# Altium schematic sheet Power_VCC.SchDoc("Power VCC")
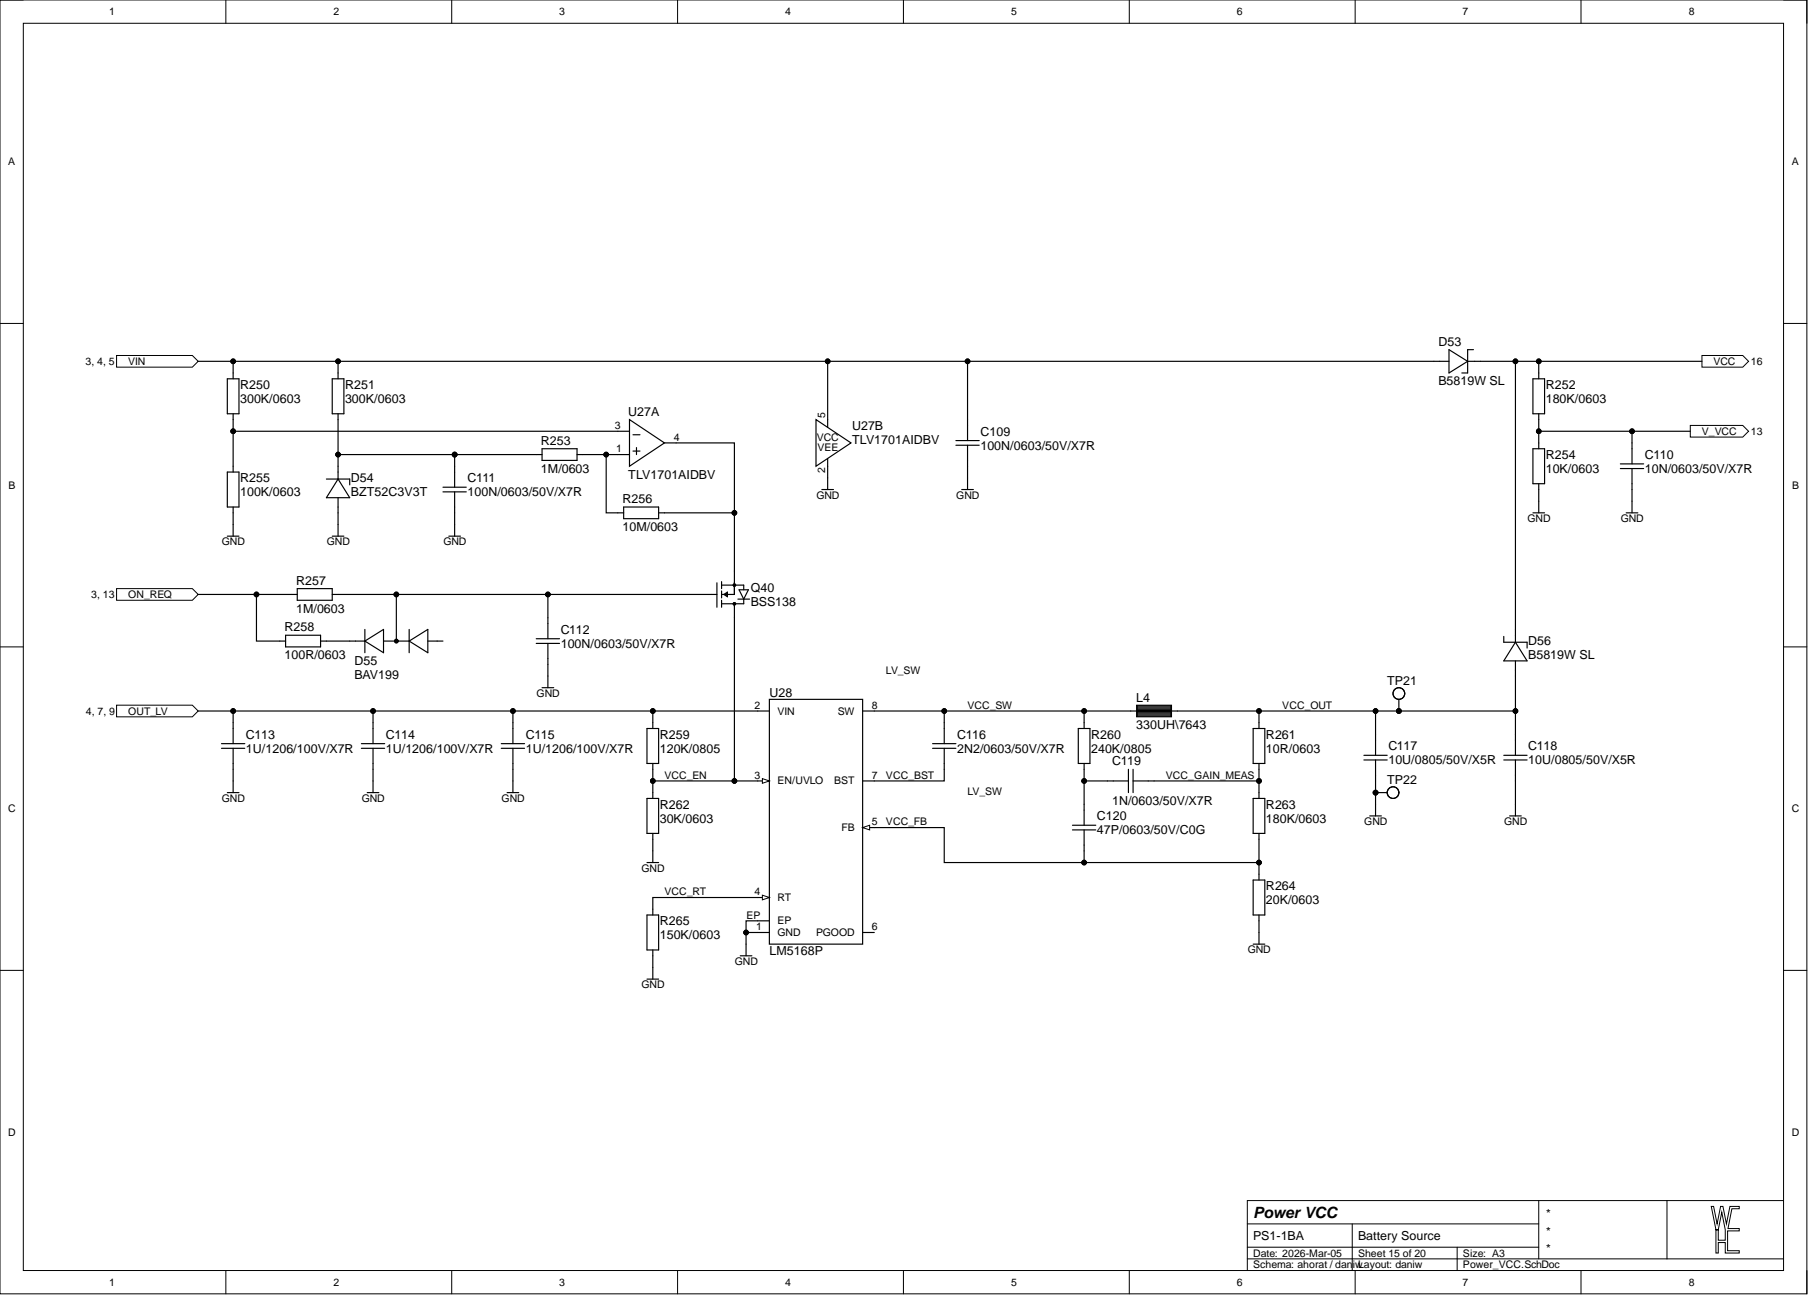
[diagram p0: Power_VCC.SchDoc]

## Parts
C109  100N/0603/50V/X7R  [CAP0603_X7R]
C110  10N/0603/50V/X7R  [CAP0603_X7R]
C111  100N/0603/50V/X7R  [CAP0603_X7R]
C112  100N/0603/50V/X7R  [CAP0603_X7R]
C113  1U/1206/100V/X7R  [CAP1206-X7R]
C114  1U/1206/100V/X7R  [CAP1206-X7R]
C115  1U/1206/100V/X7R  [CAP1206-X7R]
C116  2N2/0603/50V/X7R  [CAP0603_X7R]
C117  10U/0805/50V/X5R  [CAP0805_X7R]
C118  10U/0805/50V/X5R  [CAP0805_X7R]
C119  1N/0603/50V/X7R  [CAP0603_X7R]
C120  47P/0603/50V/C0G  [CAP0603_C0G]
D53  B5819W SL  [SOD3718X125]
D54  BZT52C3V3T  [SOD1608X065]
D55  BAV199  [SOT2395P280X125-3-SE]
D56  B5819W SL  [SOD3718X125]
L4  330UH\7643  [INDM7676X43]
Q40  BSS138  [SOT2395P280X125-3-SE]
R250  300K/0603  [R0603-0.40-WW]
R251  300K/0603  [R0603-0.40-WW]
R252  180K/0603  [R0603-0.40-WW]
R253  1M/0603  [R0603-0.40-WW]
R254  10K/0603  [R0603-0.40-WW]
R255  100K/0603  [R0603-0.40-WW]
R256  10M/0603  [R0603-0.40-WW]
R257  1M/0603  [R0603-0.40-WW]
R258  100R/0603  [R0603-0.40-WW]
R259  120K/0805  [R0805-0.50-WW]
R260  240K/0805  [R0805-0.50-WW]
R261  10R/0603  [R0603-0.40-WW]
R262  30K/0603  [R0603-0.40-WW]
R263  180K/0603  [R0603-0.40-WW]
R264  20K/0603  [R0603-0.40-WW]
R265  150K/0603  [R0603-0.40-WW]
TP21  TP_THD_1.5/0.8  [TP_THD_1.5/0.8]  (value not drawn)  (no BOM)
TP22  TP_THD_1.5/0.8  [TP_THD_1.5/0.8]  (value not drawn)  (no BOM)
U27  TLV1701AIDBV  [SOT2395P280X125-5-NW]  (parts A,B)
U28  LM5168P  [SOP127P600X155-8-EP330x240-NW]

## Ports
ON_REQ, OUT_LV, V_VCC, VCC, VIN

## Nets
GND: C109.2 C110.2 C111.2 C112.2 C113.2 C114.2 C115.2 C117.2 C118.2 D54.2 R254.2 R255.2 R262.2 R264.2 R265.2 TP22.1 U27.2 U28.1(GND) U28.EP
ON_REQ: R257.1 R258.1 ; port ON_REQ
OUT_LV: C113.1 C114.1 C115.1 R259.1 U28.2(VIN) ; port OUT_LV
V_VCC: C110.1 R252.2 R254.1 ; port V_VCC
VCC: D53.1 D56.1 R252.1 ; port VCC
VCC_BST: C116.2 U28.7(BST)
VCC_EN: Q40.3 R259.2 R262.1 U28.3(EN/UVLO)
VCC_FB: C120.2 R263.2 R264.1 U28.5(FB)
VCC_GAIN_MEAS: C119.2 R261.2 R263.1
VCC_OUT: C117.1 C118.1 D56.2 L4.2 R261.1 TP21.1
VCC_RT: R265.1 U28.4(RT)
VCC_SW: C116.1 L4.1 R260.1 U28.8(SW)
VIN: C109.1 D53.2 R250.1 R251.1 U27.5 ; port VIN
NetC111_1: C111.1 D54.1 R251.2 R253.1
NetC112_1: C112.1 D55.3 Q40.1 R257.2
NetC119_1: C119.1 C120.1 R260.2
NetD55_1: D55.1
NetD55_2: D55.2 R258.2
NetQ40_2: Q40.2 R256.2 U27.4
NetR250_2: R250.2 R255.1 U27.3
NetR253_2: R253.2 R256.1 U27.1
NetU28_6: U28.6(PGOOD)

## Library data of the parts
C109 C111 C112 = 100N/0603/50V/X7R: CAP SM 0603 100nF 50V X7R; Manufacturer: GENERIC; Manufacturer 1: YAGEO; Manufacturer Part Number 1: CC0603KPX7R9BB104; Absolute Value: 1E-7; Database Table Name: C$; Eurocircuits GPN: GPC0603104; Component Kind: Standard; HelpURL: https://www.yageo.com/upload/media/product/app/datasheet/mlcc/upy-gphc_x7r_6_3v-to-250v.pdf; JLC Type: Basic; Manufacturer 2: YAGEO; Manufacturer Part Number 2: CC0603MRX7R9BB104; Manufacturer Part Number 3: CC0603KRX7R9BB104; Package: 0603; Part Number: 100N/0603/50V/X7R; Supplier 1: Digi-Key; Supplier 2: Digi-Key; Supplier 3: LCSC; Supplier Part Number 1: 311-3567-1-ND; Supplier Part Number 2: 311-4110-1-ND; Supplier Part Number 3: C14663; Value: 100nF; Library Name: daniw_altium_lib.DbLib
C110 = 10N/0603/50V/X7R: CAP SM 0603 10nF 50V X7R; Manufacturer: GENERIC; Manufacturer 1: YAGEO; Manufacturer Part Number 1: CC0603KPX7R9BB103; Absolute Value: 1E-8; Database Table Name: C$; Eurocircuits GPN: GPC0603103; Component Kind: Standard; HelpURL: https://www.yageo.com/upload/media/product/app/datasheet/mlcc/upy-gphc_x7r_6_3v-to-250v.pdf; Manufacturer 2: YAGEO; Manufacturer Part Number 2: CC0603KRX7R9BB103; Manufacturer Part Number 3: CC0603KRX7R9BB103; Package: 0603; Part Number: 10N/0603/50V/X7R; Supplier 1: Digi-Key; Supplier 2: Digi-Key; Supplier 3: LCSC; Supplier Part Number 1: 311-1572-1-ND; Supplier Part Number 2: 311-1085-1-ND; Supplier Part Number 3: C100042; Value: 10nF; Library Name: daniw_altium_lib.DbLib
C113-C115 = 1U/1206/100V/X7R: CAP SM 1206 1uF 100V X7R; Manufacturer: GENERIC; Manufacturer 1: Samsung Electro-Mechanics; Manufacturer Part Number 1: CL31B105KCHNNNE; Absolute Value: 1E-6; Database Table Name: C$; Component Kind: Standard; HelpURL: https://mm.digikey.com/Volume0/opasdata/d220001/medias/docus/41/CL31B105KCHNNNE_Spec.pdf; Manufacturer Part Number 3: TCC1206X7R105K101HT; Package: 1206; Part Number: 1U/1206/100V/X7R; Supplier 1: Digi-Key; Supplier 3: LCSC; Supplier Part Number 1: 1276-1838-1-ND; Supplier Part Number 3: C282823; Value: 1UF; Library Name: daniw_altium_lib.DbLib
C116 = 2N2/0603/50V/X7R: CAP SM 0603 2.2nF 50V X7R; Manufacturer: GENERIC; Manufacturer 1: YAGEO; Manufacturer Part Number 1: CC0603KPX7R9BB222; Absolute Value: 2.2E-9; Database Table Name: C$; Eurocircuits GPN: GPC0603222; Component Kind: Standard; HelpURL: https://www.yageo.com/upload/media/product/app/datasheet/mlcc/upy-gphc_x7r_6_3v-to-250v.pdf; JLC Type: Basic; Manufacturer 2: YAGEO; Manufacturer Part Number 2: CC0603KRX7R9BB222; Manufacturer Part Number 3: 0603B222K500NT; Package: 0603; Part Number: 2N2/0603/50V/X7R; Supplier 1: Digi-Key; Supplier 2: Digi-Key; Supplier 3: LCSC; Supplier Part Number 1: 13-CC0603KPX7R9BB222CT-ND; Supplier Part Number 2: 311-1081-1-ND; Supplier Part Number 3: C1604; Value: 2.2nF; Library Name: daniw_altium_lib.DbLib
C117 C118 = 10U/0805/50V/X5R: CAP SM 0805 10uF 50V X5R; Manufacturer: GENERIC; Manufacturer 1: Murata Electronics; Manufacturer Part Number 1: GRM21BR61H106KE43K; Absolute Value: 1E-5; Database Table Name: C$; Eurocircuits GPN: GPN0805106-50-X5R; Component Kind: Standard; HelpURL: https://search.murata.co.jp/Ceramy/image/img/A01X/G101/ENG/GRM21BR61H106KE43-01A.pdf; JLC Type: Basic; Manufacturer Part Number 3: GRM21BR61H106KE43L; Package: 0805; Part Number: 10U/0805/50V/X5R; Supplier 1: Digi-Key; Supplier 3: LCSC; Supplier Part Number 1: 490-GRM21BR61H106KE43KCT-ND; Supplier Part Number 3: C440198; Value: 10uF; Library Name: daniw_altium_lib.DbLib
C119 = 1N/0603/50V/X7R: CAP SM 0603 1.0nF 50V X7R; Manufacturer: GENERIC; Manufacturer 1: YAGEO; Manufacturer Part Number 1: CC0603KPX7R9BB102; Absolute Value: 1E-9; Database Table Name: C$; Eurocircuits GPN: GPC0603102; Component Kind: Standard; HelpURL: https://www.yageo.com/upload/media/product/app/datasheet/mlcc/upy-gphc_x7r_6_3v-to-250v.pdf; JLC Type: Basic; Manufacturer 2: YAGEO; Manufacturer Part Number 2: CC0603KRX7R9BB102; Manufacturer Part Number 3: CL10B102KB8NNNC; Package: 0603; Part Number: 1N/0603/50V/X7R; Supplier 1: Digi-Key; Supplier 2: Digi-Key; Supplier 3: LCSC; Supplier Part Number 1: 13-CC0603KPX7R9BB102CT-ND; Supplier Part Number 2: 311-1080-1-ND; Supplier Part Number 3: C1588; Value: 1.0nF; Library Name: daniw_altium_lib.DbLib
C120 = 47P/0603/50V/C0G: CAP SM 0603 47pF 50V C0G; Manufacturer: GENERIC; Manufacturer 1: YAGEO; Manufacturer Part Number 1: CC0603JRNPO9BN470; Absolute Value: 4.7E-11; Database Table Name: C$; Eurocircuits GPN: GPC0603470-C0G; Component Kind: Standard; HelpURL: https://www.yageo.com/upload/media/product/productsearch/datasheet/mlcc/UPY-GP_NP0_16V-to-50V_18.pdf; JLC Type: Basic; Manufacturer 2: YAGEO; Manufacturer Part Number 2: CC0603KRNPO9BN470; Manufacturer Part Number 3: CL10C470JB8NNNC; Package: 0603; Part Number: 47P/0603/50V/C0G; Supplier 1: Digi-Key; Supplier 2: Digi-Key; Supplier 3: LCSC; Supplier Part Number 1: 311-1065-1-ND; Supplier Part Number 2: 311-4037-1-ND; Supplier Part Number 3: C1671; Value: 47pF; Library Name: daniw_altium_lib.DbLib
D53 D56 = B5819W SL: 40V 600mV@1A 1A SOD-123 Schottky Barrier Diodes; Manufacturer: GENERIC; Manufacturer 1: SMC Diode Solutions; Database Table Name: D$; Absolute Value: 40V; Component Kind: Standard; HelpURL: https://datasheet.lcsc.com/lcsc/2304140030_Jiangsu-Changjing-Electronics-Technology-Co---Ltd--B5819W-SL_C8598.pdf; JLC Type: Basic; Manufacturer 3: Jiangsu Changjing Electronics Technology Co., Ltd.; Manufacturer Part Number 1: B5819WSL; Manufacturer Part Number 3: B5819W SL; Package: SOD-123; Part Number: B5819W SL; Supplier 1: Digikey; Supplier 3: LCSC; Supplier Part Number 1: 1655-B5819WSLCT-ND; Supplier Part Number 3: C8598; Value: B5819W SL; Library Name: daniw_altium_lib.DbLib
D54 = BZT52C3V3T: DIODE ZENER 3.3V 300MW SOD523; Manufacturer: Diodes Incorporated; Manufacturer Part Number 1: BZT52C3V3T-7; Database Table Name: D$; Absolute Value: 3.3 V; Component Kind: Standard; HelpURL: https://www.diodes.com/assets/Datasheets/ds30502.pdf; Manufacturer 1: Diodes Incorporated; Manufacturer 2: Micro Commercial Co; Manufacturer 3: BORN; Manufacturer Part Number 2: BZT52C3V3T-TP; Manufacturer Part Number 3: BZT52C3V3T; Package: SC-79, SOD-523; Part Number: BZT52C3V3T; Supplier 1: Digikey; Supplier 2: Digikey; Supplier 3: LCSC; Supplier Part Number 1: BZT52C3V3T-7DICT-ND; Supplier Part Number 2: BZT52C3V3T-TPMSCT-ND; Supplier Part Number 3: C20612286; Value: BZT52C3V3T; Library Name: daniw_altium_lib.DbLib
D55 = BAV199: Silicon Low Leakage Diode 85V; Manufacturer: Infineon Technologies; Manufacturer Part Number 1: BAV199E6433HTMA1; Database Table Name: D$; Absolute Value: 85V; Component Kind: Standard; HelpURL: https://www.infineon.com/dgdl/bav199series.pdf?folderId=db3a30431400ef6801141c748874044e&fileId=db3a30431400ef6801141cba733104e5; Manufacturer 1: Infineon Technologies; Manufacturer 3: LRC; Manufacturer Part Number 3: LBAV199LT1G; Package: SOT23; Part Number: BAV199; Supplier 1: Digikey; Supplier 3: LCSC; Supplier Part Number 1: BAV199E6433HTMA1CT-ND; Supplier Part Number 3: C12740; Value: BAV199; Library Name: daniw_altium_lib.DbLib
L4 = 330UH\7643: FIXED IND 330UH 450MA 1.41OHM SM; Manufacturer: Eaton - Electronics Division; Manufacturer Part Number 1: DR74-331-R; Absolute Value: 0.00033; Database Table Name: L$; Component Kind: Standard; HelpURL: https://www.eaton.com/content/dam/eaton/products/electronic-components/resources/data-sheet/eaton-dr-high-power-density-high-efficiency-shielded-drum-core-power-inductors-data-sheet.pdf; Manufacturer 1: Eaton - Electronics Division; Manufacturer 3: SXN(Shun Xiang Nuo Elec); Manufacturer Part Number 3: SMDRI74-331MT; Package: 7643; Part Number: 330UH\7643; Supplier 1: Digi-Key; Supplier 3: LCSC; Supplier Part Number 1: 283-4601-1-ND; Supplier Part Number 3: C35560; Value: 330UH; Library Name: daniw_altium_lib.DbLib
Q40 = BSS138: N-Kanal 50 V 220mA (Ta) 350mW (Ta) Oberflächenmontage SOT-23; Manufacturer: GENERIC; Manufacturer 1: ANBON SEMICONDUCTOR (INT'L) LIMITED; Database Table Name: T$; Component Kind: Standard; HelpURL: https://mm.digikey.com/Volume0/opasdata/d220001/medias/docus/3037/BSS138.pdf; JLC Type: Extended; Manufacturer 2: FOSAN; Manufacturer 3: FOSAN; Manufacturer Part Number 1: BSS138; Manufacturer Part Number 2: BSS138; Manufacturer Part Number 3: BSS138; Package: SOT23; Part Number: BSS138; Supplier 1: Digi-Key; Supplier 2: LCSC; Supplier 3: LCSC; Supplier Part Number 1: 4530-BSS138CT-ND; Supplier Part Number 2: C2926186; Supplier Part Number 3: C2926186; Library Name: daniw_altium_lib.DbLib
R250 R251 = 300K/0603: RES SM 0603 300K; Manufacturer: GENERIC; Manufacturer 1: YAGEO; Manufacturer Part Number 1: RC0603FR-13300KL; Absolute Value: 300000; Database Table Name: R$; Eurocircuits GPN: GPR0603300K; Component Kind: Standard; HelpURL: https://www.yageogroup.com/content/datasheet/asset/file/PYU-RC_GROUP_51_ROHS_L; JLC Type: Basic; Manufacturer 2: YAGEO; Manufacturer 3: UNI-ROYAL(Uniroyal Elec); Manufacturer Part Number 2: RC0603FR-10300KL; Manufacturer Part Number 3: 0603WAF3003T5E; Package: 0603; Part Number: 300K/0603; Supplier 1: Digi-Key; Supplier 2: Digi-Key; Supplier 3: LCSC; Supplier Part Number 1: 13-RC0603FR-13300KLCT-ND; Supplier Part Number 2: 13-RC0603FR-10300KLCT-ND; Supplier Part Number 3: C23024; Value: 300K; Library Name: daniw_altium_lib.DbLib
R252 R263 = 180K/0603: RES SM 0603 180K; Manufacturer: GENERIC; Manufacturer 1: YAGEO; Manufacturer Part Number 1: RC0603FR-13180KL; Absolute Value: 180000; Database Table Name: R$; Eurocircuits GPN: GPR0603180K; Component Kind: Standard; HelpURL: https://www.yageogroup.com/content/datasheet/asset/file/PYU-RC_GROUP_51_ROHS_L; JLC Type: Extended; Manufacturer 2: YAGEO; Manufacturer 3: UNI-ROYAL(Uniroyal Elec); Manufacturer Part Number 2: RC0603FR-10180KL; Manufacturer Part Number 3: 0603WAF1803T5E; Package: 0603; Part Number: 180K/0603; Supplier 1: Digi-Key; Supplier 2: Digi-Key; Supplier 3: LCSC; Supplier Part Number 1: 13-RC0603FR-13180KLCT-ND; Supplier Part Number 2: 13-RC0603FR-10180KLCT-ND; Supplier Part Number 3: C22827; Value: 180K; Library Name: daniw_altium_lib.DbLib
R253 R257 = 1M/0603: RES SM 0603 1M; Manufacturer: GENERIC; Manufacturer 1: YAGEO; Manufacturer Part Number 1: RC0603FR-131ML; Absolute Value: 1000000; Database Table Name: R$; Eurocircuits GPN: GPR06031M; Component Kind: Standard; HelpURL: https://www.yageogroup.com/content/datasheet/asset/file/PYU-RC_GROUP_51_ROHS_L; JLC Type: Basic; Manufacturer 2: YAGEO; Manufacturer 3: UNI-ROYAL(Uniroyal Elec); Manufacturer Part Number 2: RC0603FR-101ML; Manufacturer Part Number 3: 0603WAF1004T5E; Package: 0603; Part Number: 1M/0603; Supplier 1: Digi-Key; Supplier 2: Digi-Key; Supplier 3: LCSC; Supplier Part Number 1: 13-RC0603FR-131MLCT-ND; Supplier Part Number 2: 13-RC0603FR-101MLCT-ND; Supplier Part Number 3: C22935; Value: 1M; Library Name: daniw_altium_lib.DbLib
R254 = 10K/0603: RES SM 0603 10K; Manufacturer: GENERIC; Manufacturer 1: YAGEO; Manufacturer Part Number 1: RC0603FR-1310KL; Absolute Value: 10000; Database Table Name: R$; Eurocircuits GPN: GPR060310K; Component Kind: Standard; HelpURL: https://www.yageogroup.com/content/datasheet/asset/file/PYU-RC_GROUP_51_ROHS_L; JLC Type: Basic; Manufacturer 2: YAGEO; Manufacturer 3: UNI-ROYAL(Uniroyal Elec); Manufacturer Part Number 2: RC0603FR-1010KL; Manufacturer Part Number 3: 0603WAF1002T5E; Package: 0603; Part Number: 10K/0603; Supplier 1: Digi-Key; Supplier 2: Digi-Key; Supplier 3: LCSC; Supplier Part Number 1: 13-RC0603FR-1310KLCT-ND; Supplier Part Number 2: 13-RC0603FR-1010KLCT-ND; Supplier Part Number 3: C25804; Value: 10K; Library Name: daniw_altium_lib.DbLib
R255 = 100K/0603: RES SM 0603 100K; Manufacturer: GENERIC; Manufacturer 1: YAGEO; Manufacturer Part Number 1: RC0603FR-13100KL; Absolute Value: 100000; Database Table Name: R$; Eurocircuits GPN: GPR0603100K; Component Kind: Standard; HelpURL: https://www.yageogroup.com/content/datasheet/asset/file/PYU-RC_GROUP_51_ROHS_L; JLC Type: Basic; Manufacturer 2: YAGEO; Manufacturer 3: UNI-ROYAL(Uniroyal Elec); Manufacturer Part Number 2: RC0603FR-10100KL; Manufacturer Part Number 3: 0603WAF1003T5E; Package: 0603; Part Number: 100K/0603; Supplier 1: Digi-Key; Supplier 2: Digi-Key; Supplier 3: LCSC; Supplier Part Number 1: 13-RC0603FR-13100KLCT-ND; Supplier Part Number 2: 13-RC0603FR-10100KLCT-ND; Supplier Part Number 3: C25803; Value: 100K; Library Name: daniw_altium_lib.DbLib
R256 = 10M/0603: RES SM 0603 10M; Manufacturer: GENERIC; Manufacturer 1: YAGEO; Manufacturer Part Number 1: RC0603FR-1310ML; Absolute Value: 10000000; Database Table Name: R$; Eurocircuits GPN: GPR060310M; Component Kind: Standard; HelpURL: https://www.yageogroup.com/content/datasheet/asset/file/PYU-RC_GROUP_51_ROHS_L; JLC Type: Basic; Manufacturer 2: YAGEO; Manufacturer 3: UNI-ROYAL(Uniroyal Elec); Manufacturer Part Number 2: RC0603FR-1010ML; Manufacturer Part Number 3: 0603WAF1005T5E; Package: 0603; Part Number: 10M/0603; Supplier 1: Digi-Key; Supplier 2: Digi-Key; Supplier 3: LCSC; Supplier Part Number 1: 13-RC0603FR-1310MLCT-ND; Supplier Part Number 2: 13-RC0603FR-1010MLCT-ND; Supplier Part Number 3: C7250; Value: 10M; Library Name: daniw_altium_lib.DbLib
R258 = 100R/0603: RES SM 0603 100R; Manufacturer: GENERIC; Manufacturer 1: YAGEO; Manufacturer Part Number 1: RC0603FR-13100RL; Absolute Value: 100; Database Table Name: R$; Eurocircuits GPN: GPR0603100R; Component Kind: Standard; HelpURL: https://www.yageogroup.com/content/datasheet/asset/file/PYU-RC_GROUP_51_ROHS_L; Manufacturer 2: YAGEO; Manufacturer 3: UNI-ROYAL(Uniroyal Elec); Manufacturer Part Number 2: RC0603FR-10100RL; Manufacturer Part Number 3: 0603WAF1000T5E; Package: 0603; Part Number: 100R/0603; Supplier 1: Digi-Key; Supplier 2: Digi-Key; Supplier 3: LCSC; Supplier Part Number 1: 13-RC0603FR-13100RLCT-ND; Supplier Part Number 2: 13-RC0603FR-10100RLCT-ND; Supplier Part Number 3: C22775; Value: 100R; Library Name: daniw_altium_lib.DbLib
R259 = 120K/0805: RES SM 0805 120K; Manufacturer: GENERIC; Manufacturer 1: YAGEO; Manufacturer Part Number 1: RC0805FR-13120KL; Absolute Value: 120000; Database Table Name: R$; Eurocircuits GPN: GPR0805120K; Component Kind: Standard; HelpURL: https://www.yageogroup.com/content/datasheet/asset/file/PYU-RC_GROUP_51_ROHS_L; JLC Type: Extended; Manufacturer 2: YAGEO; Manufacturer 3: YAGEO; Manufacturer Part Number 2: RC0805FR-07120KL; Manufacturer Part Number 3: RC0805FR-07120KL; Package: 0805; Part Number: 120K/0805; Supplier 1: Digi-Key; Supplier 2: Digi-Key; Supplier 3: LCSC; Supplier Part Number 1: 13-RC0805FR-13120KLCT-ND; Supplier Part Number 2: 311-120KCRCT-ND; Supplier Part Number 3: C114524; Value: 120K; Library Name: daniw_altium_lib.DbLib
R260 = 240K/0805: RES SM 0805 240K; Manufacturer: GENERIC; Manufacturer 1: YAGEO; Manufacturer Part Number 1: RC0805FR-10240KL; Absolute Value: 240000; Database Table Name: R$; Eurocircuits GPN: GPR0805240K; Component Kind: Standard; HelpURL: https://www.yageogroup.com/content/datasheet/asset/file/PYU-RC_GROUP_51_ROHS_L; JLC Type: Extended; Manufacturer 2: YAGEO; Manufacturer 3: FOJAN; Manufacturer Part Number 2: RC0805FR-07240KL; Manufacturer Part Number 3: FRC0805J244; Package: 0805; Part Number: 240K/0805; Supplier 1: Digi-Key; Supplier 2: Digi-Key; Supplier 3: LCSC; Supplier Part Number 1: 13-RC0805FR-10240KLCT-ND; Supplier Part Number 2: 311-240KCRCT-ND; Supplier Part Number 3: C2960883; Value: 240K; Library Name: daniw_altium_lib.DbLib
R261 = 10R/0603: RES SM 0603 10R; Manufacturer: GENERIC; Manufacturer 1: YAGEO; Manufacturer Part Number 1: RC0603FR-1310RL; Absolute Value: 10; Database Table Name: R$; Eurocircuits GPN: GPR060310R; Component Kind: Standard; HelpURL: https://www.yageogroup.com/content/datasheet/asset/file/PYU-RC_GROUP_51_ROHS_L; JLC Type: Basic; Manufacturer 2: YAGEO; Manufacturer 3: UNI-ROYAL; Manufacturer Part Number 2: RC0603FR-1010RL; Manufacturer Part Number 3: 0603WAF100JT5E; Package: 0603; Part Number: 10R/0603; Supplier 1: Digi-Key; Supplier 2: Digi-Key; Supplier 3: LCSC; Supplier Part Number 1: 13-RC0603FR-1310RLCT-ND; Supplier Part Number 2: 13-RC0603FR-1010RLCT-ND; Supplier Part Number 3: C22859; Value: 10R; Library Name: daniw_altium_lib.DbLib
R262 = 30K/0603: RES SM 0603 30K; Manufacturer: GENERIC; Manufacturer 1: YAGEO; Manufacturer Part Number 1: RC0603FR-1330KL; Absolute Value: 30000; Database Table Name: R$; Eurocircuits GPN: GPR060330K; Component Kind: Standard; HelpURL: https://www.yageogroup.com/content/datasheet/asset/file/PYU-RC_GROUP_51_ROHS_L; JLC Type: Basic; Manufacturer 2: YAGEO; Manufacturer 3: UNI-ROYAL(Uniroyal Elec); Manufacturer Part Number 2: RC0603FR-1030KL; Manufacturer Part Number 3: 0603WAF3002T5E; Package: 0603; Part Number: 30K/0603; Supplier 1: Digi-Key; Supplier 2: Digi-Key; Supplier 3: LCSC; Supplier Part Number 1: 13-RC0603FR-1330KLCT-ND; Supplier Part Number 2: 13-RC0603FR-1030KLCT-ND; Supplier Part Number 3: C22984; Value: 30K; Library Name: daniw_altium_lib.DbLib
R264 = 20K/0603: RES SM 0603 20K; Manufacturer: GENERIC; Manufacturer 1: YAGEO; Manufacturer Part Number 1: RC0603FR-1320KL; Absolute Value: 20000; Database Table Name: R$; Eurocircuits GPN: GPR060320K; Component Kind: Standard; HelpURL: https://www.yageogroup.com/content/datasheet/asset/file/PYU-RC_GROUP_51_ROHS_L; JLC Type: Basic; Manufacturer 2: YAGEO; Manufacturer 3: UNI-ROYAL(Uniroyal Elec); Manufacturer Part Number 2: RC0603FR-1020KL; Manufacturer Part Number 3: 0603WAF2002T5E; Package: 0603; Part Number: 20K/0603; Supplier 1: Digi-Key; Supplier 2: Digi-Key; Supplier 3: LCSC; Supplier Part Number 1: 13-RC0603FR-1320KLCT-ND; Supplier Part Number 2: 13-RC0603FR-1020KLCT-ND; Supplier Part Number 3: C4184; Value: 20K; Library Name: daniw_altium_lib.DbLib
R265 = 150K/0603: RES SM 0603 150K; Manufacturer: GENERIC; Manufacturer 1: YAGEO; Manufacturer Part Number 1: RC0603FR-13150KL; Absolute Value: 150000; Database Table Name: R$; Eurocircuits GPN: GPR0603150K; Component Kind: Standard; HelpURL: https://www.yageogroup.com/content/datasheet/asset/file/PYU-RC_GROUP_51_ROHS_L; JLC Type: Basic; Manufacturer 2: YAGEO; Manufacturer 3: UNI-ROYAL(Uniroyal Elec); Manufacturer Part Number 2: RC0603FR-10150KL; Manufacturer Part Number 3: 0603WAF1503T5E; Package: 0603; Part Number: 150K/0603; Supplier 1: Digi-Key; Supplier 2: Digi-Key; Supplier 3: LCSC; Supplier Part Number 1: 13-RC0603FR-13150KLCT-ND; Supplier Part Number 2: 13-RC0603FR-10150KLCT-ND; Supplier Part Number 3: C22807; Value: 150K; Library Name: daniw_altium_lib.DbLib
TP21 TP22 = TP_THD_1.5/0.8: THT Test Point 1.5/0.8mm; Manufacturer: NONE; Database Table Name: Misc$; Part Number: TP_THD_1.5/0.8; Library Name: daniw_altium_lib.DbLib
U27 = TLV1701 SOT23: TLV1701 2.2-V to 36-V, microPower Comparato; Manufacturer: Texas Instruments; Manufacturer Part Number 1: TLV1701AIDBVR; Database Table Name: Integrated$; Component Kind: Standard; HelpURL: https://www.ti.com/lit/ds/symlink/tlv1701.pdf; Manufacturer 1: Texas Instruments; Manufacturer 2: Texas Instruments; Manufacturer 3: Texas Instruments; Manufacturer Part Number 2: TLV1701QDBVRQ1; Manufacturer Part Number 3: TLV1701AIDBVR; Package: SOT23-5; Part Number: TLV1701 SOT23; Supplier 1: Digi-Key; Supplier 2: Digi-Key; Supplier 3: LCSC; Supplier Part Number 1: 296-40599-1-ND; Supplier Part Number 2: 296-47458-1-ND; Supplier Part Number 3: C130035; Library Name: daniw_altium_lib.DbLib
U28 = LM5168P: 0.3A, 120V Absolute Maximum, Step-Down Converter With Fly-Buck™ Converter Capability; Manufacturer: Texas Instruments; Manufacturer Part Number 1: LM5168PDDAR; Database Table Name: Integrated$; Component Kind: Standard; HelpURL: https://www.ti.com/lit/ds/symlink/lm5168.pdf; Manufacturer 1: Texas Instruments; Manufacturer 3: Texas Instruments; Manufacturer Part Number 3: LM5168PDDAR; Package: SO8-EP; Part Number: LM5168P; Supplier 1: Digi-Key; Supplier 3: LCSC; Supplier Part Number 1: 296-LM5168PDDARCT-ND; Supplier Part Number 3: C5751958; Library Name: daniw_altium_lib.DbLib
